# Altium schematic sheet [17] - HEADER, SPI, LEDS, EEPROM.SchDoc("[17] - HEADER, SPI, LEDS, EEPROM")
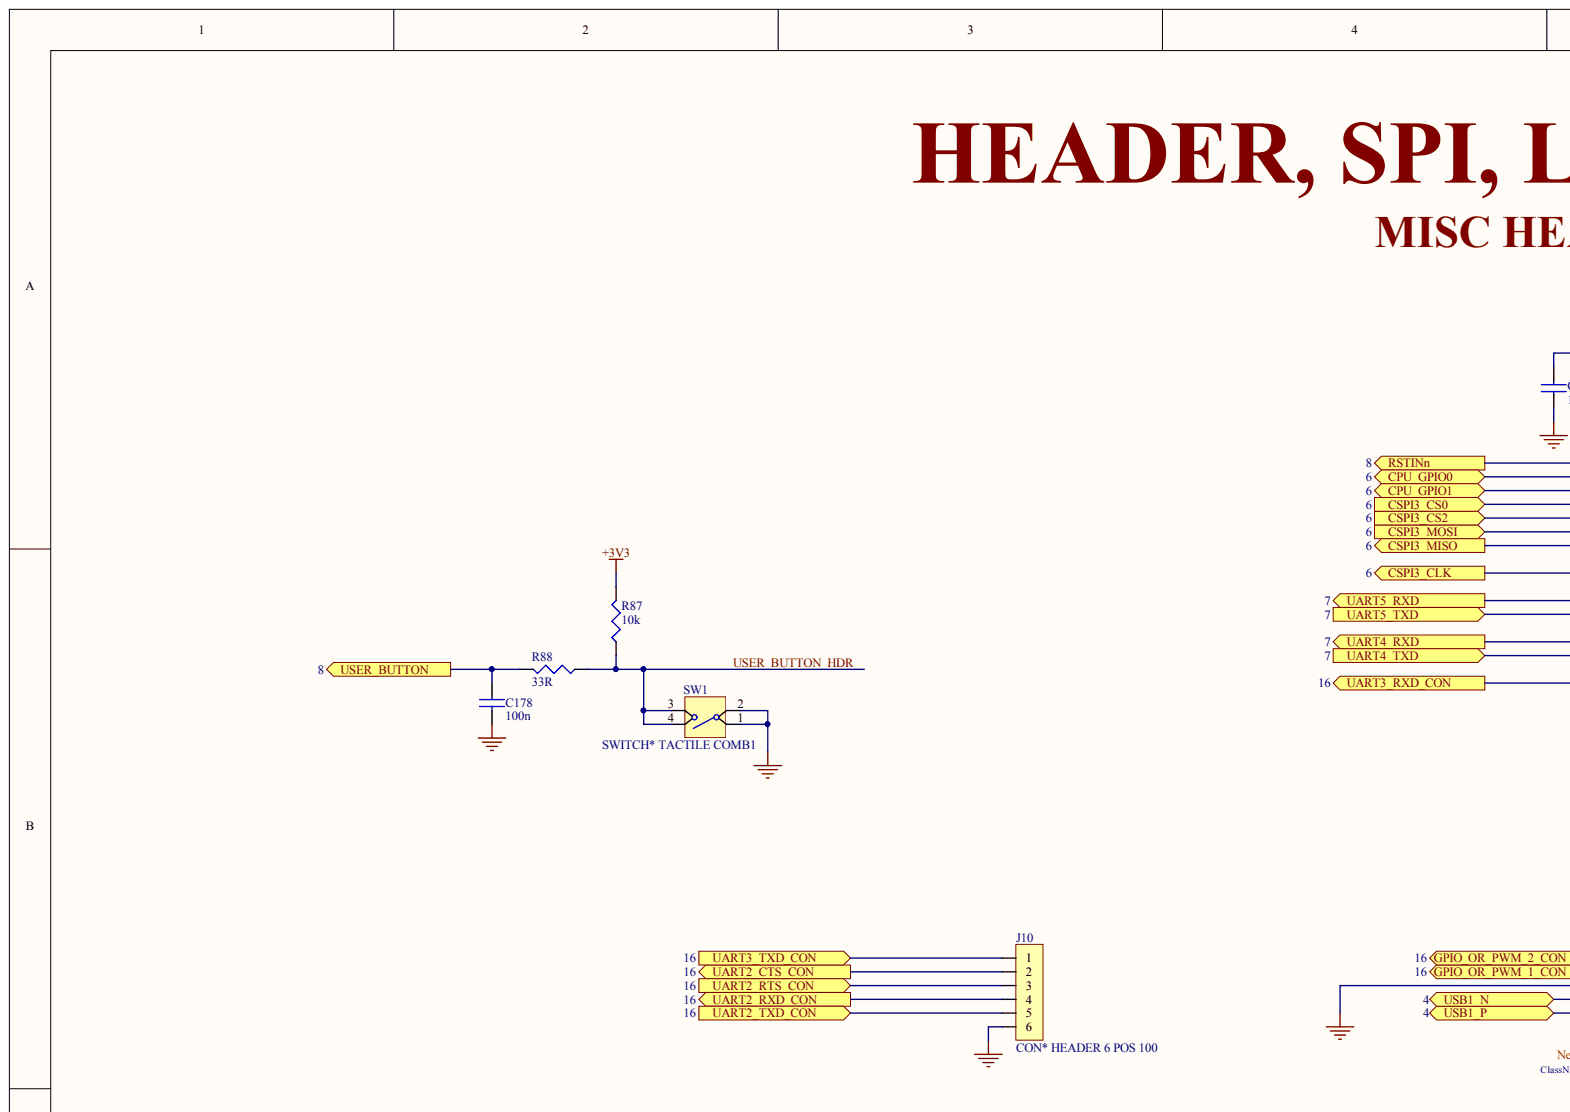
[diagram p0: [17] - HEADER, SPI, LEDS, EEPROM.SchDoc, top left]
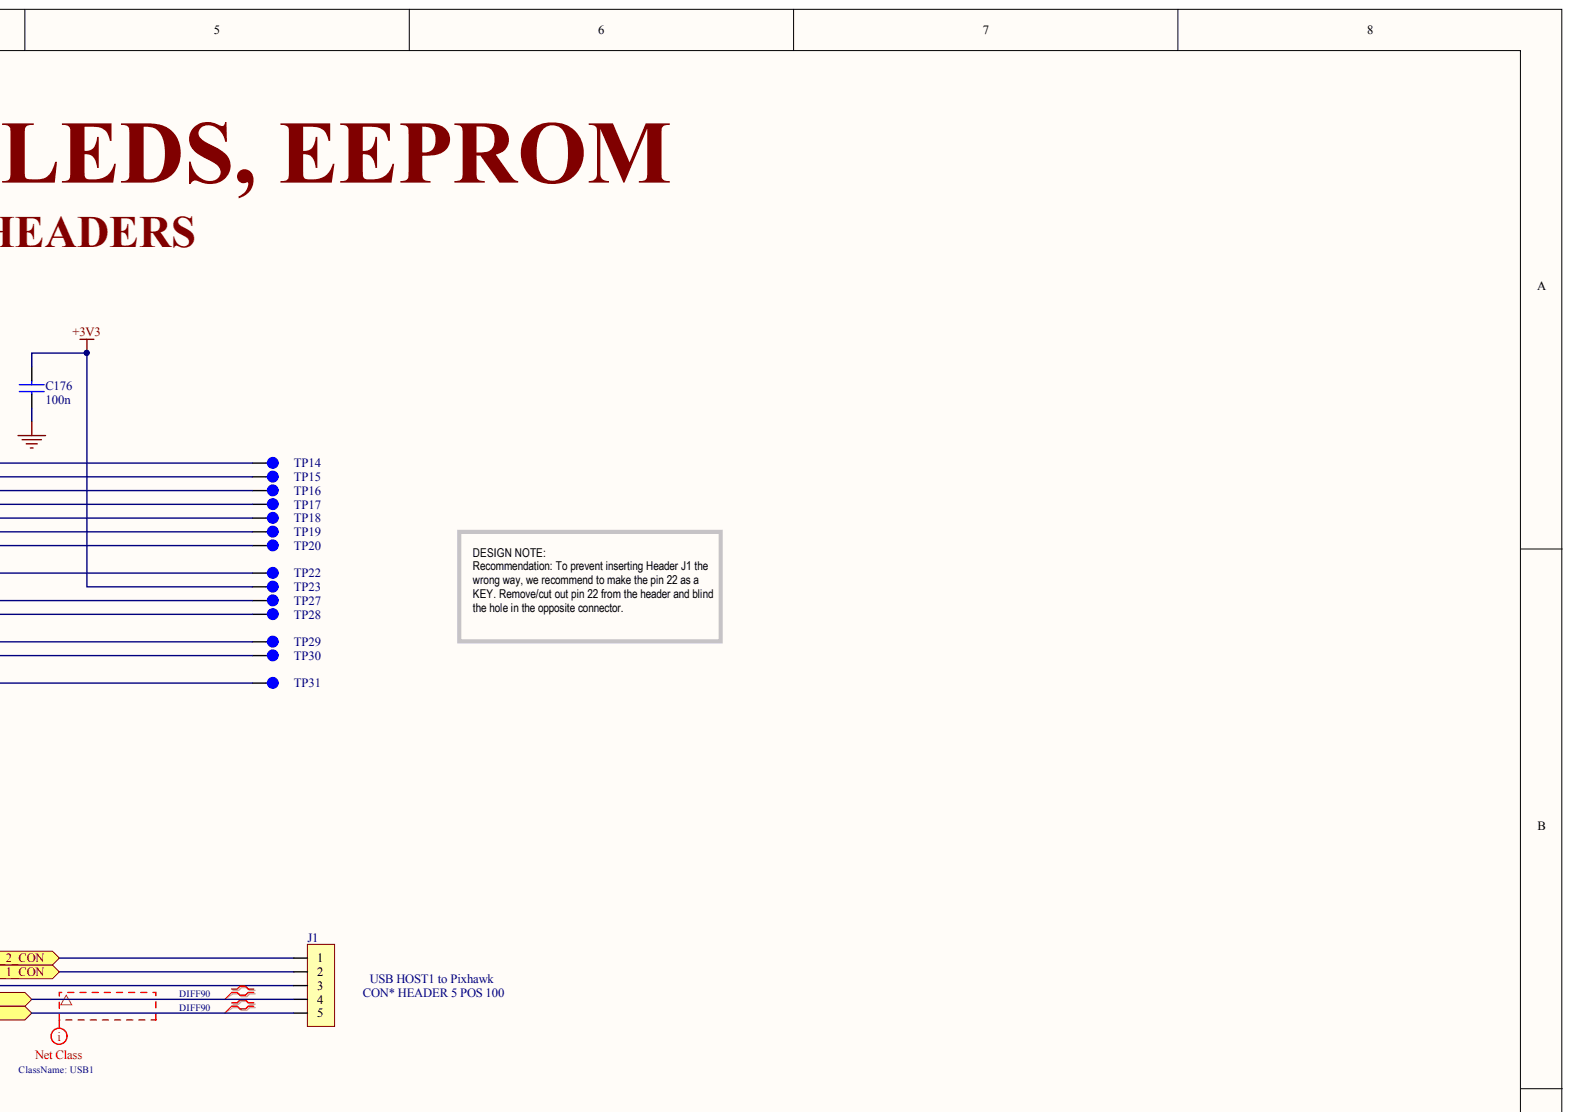
[diagram p1: [17] - HEADER, SPI, LEDS, EEPROM.SchDoc, top right]
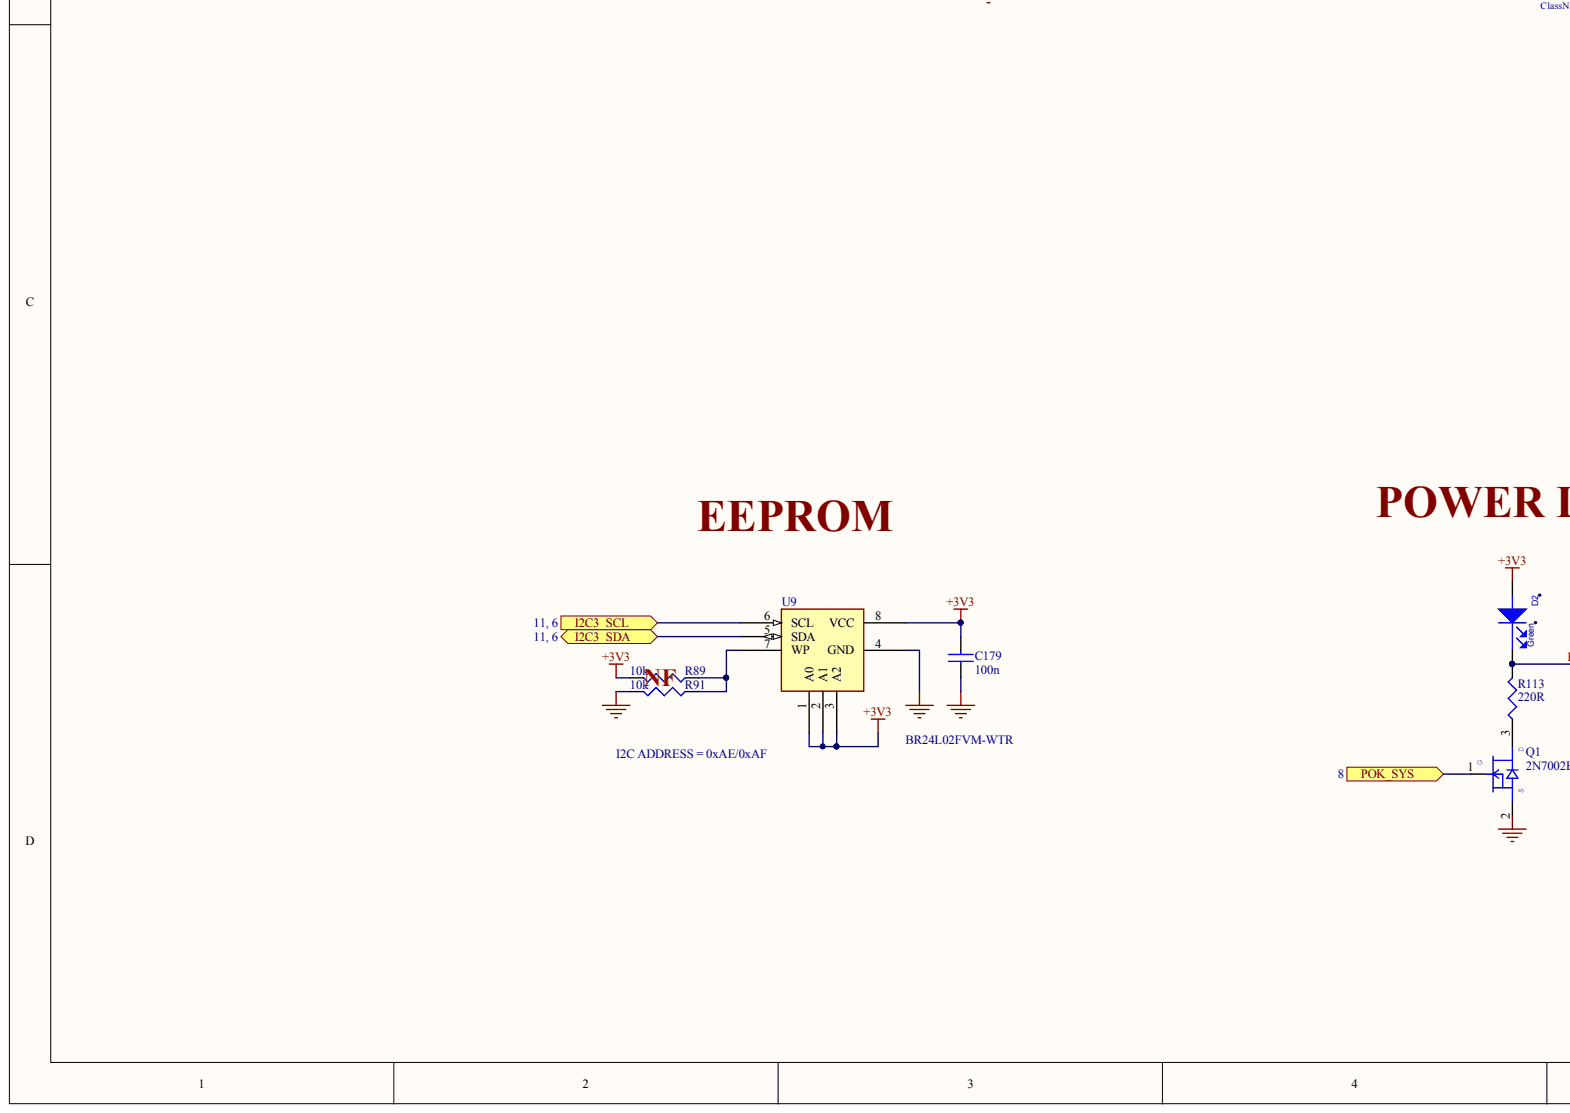
[diagram p2: [17] - HEADER, SPI, LEDS, EEPROM.SchDoc, bottom left]
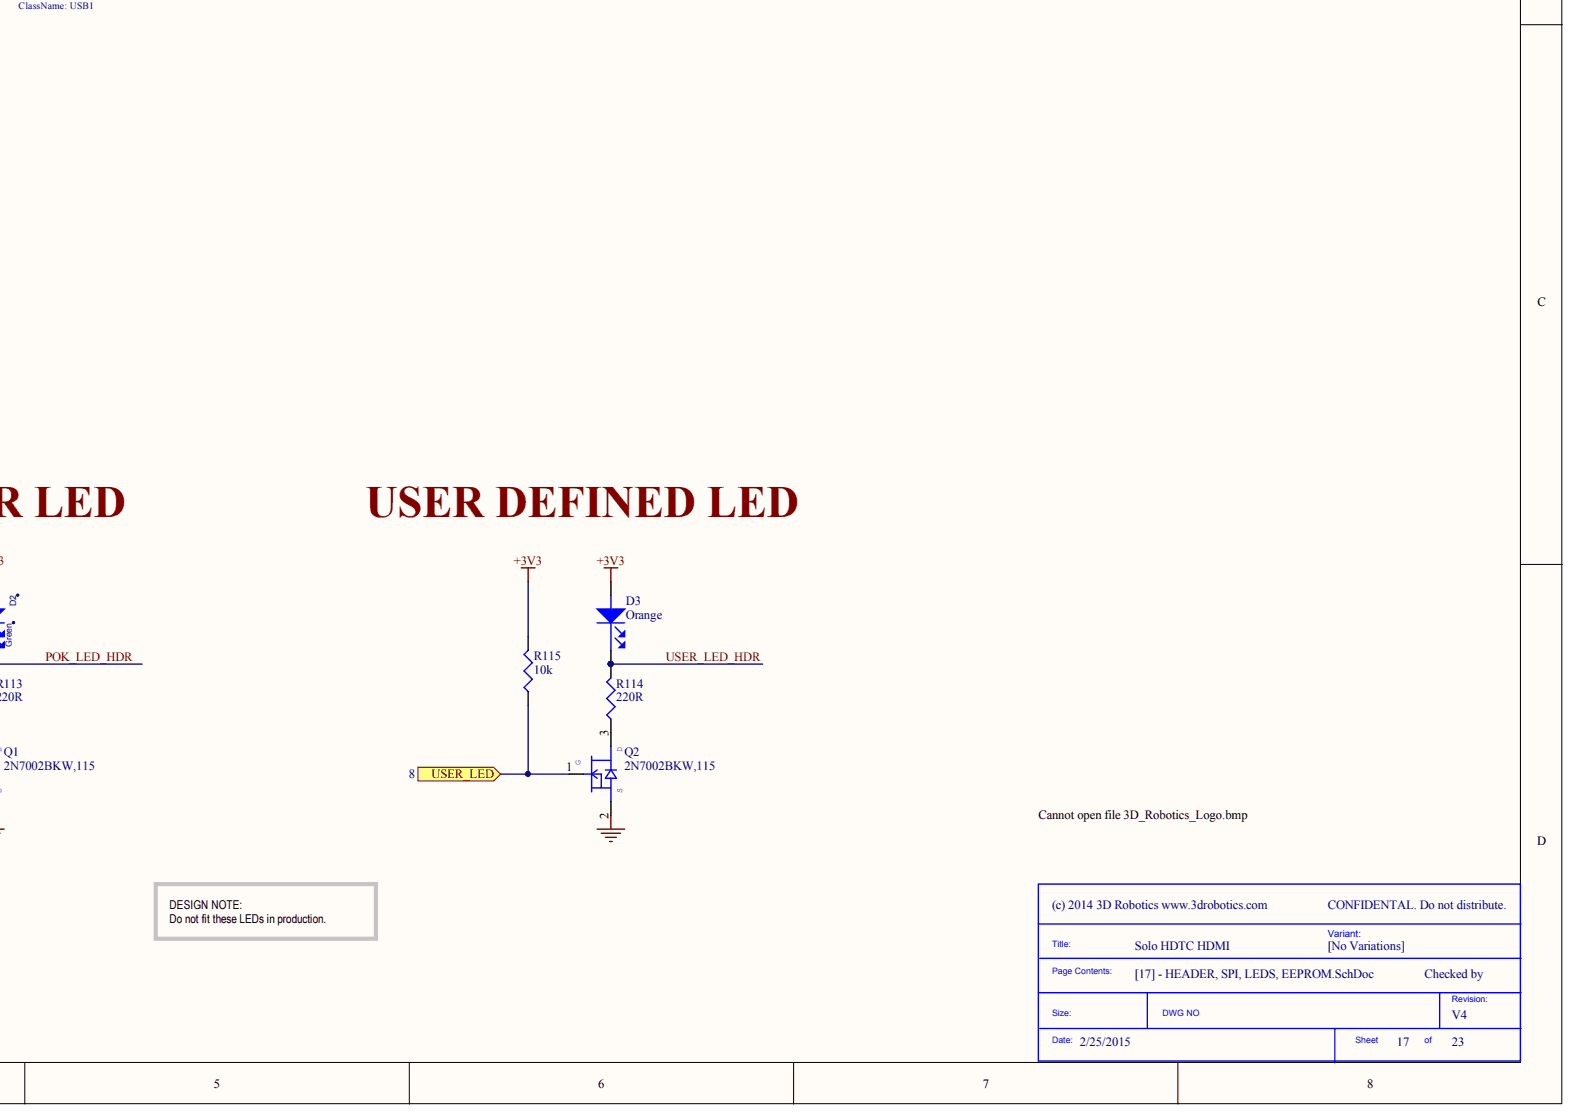
[diagram p3: [17] - HEADER, SPI, LEDS, EEPROM.SchDoc, bottom right]

## Parts
C176  100n
C178  100n
C179  100n
D2  Green
D3  Orange
J1  CON* HEADER 5 POS 100
J10  CON* HEADER 6 POS 100
Q1  2N7002BKW,115
Q2  2N7002BKW,115
R87  10k
R88  33R
R89  10k
R91  10k
R113  220R
R114  220R
R115  10k
SW1  SWITCH* TACTILE COMB1
TP14  test point  (value not drawn)
TP15  test point  (value not drawn)
TP16  test point  (value not drawn)
TP17  test point  (value not drawn)
TP18  test point  (value not drawn)
TP19  test point  (value not drawn)
TP20  test point  (value not drawn)
TP22  test point  (value not drawn)
TP23  test point  (value not drawn)
TP27  test point  (value not drawn)
TP28  test point  (value not drawn)
TP29  test point  (value not drawn)
TP30  test point  (value not drawn)
TP31  test point  (value not drawn)
U9  BR24L02FVM-WTR

## Ports
CPU_GPIO0, CPU_GPIO1, CSPI3_CLK, CSPI3_CS0, CSPI3_CS2, CSPI3_MISO, CSPI3_MOSI, GPIO_OR_PWM_1_CON, GPIO_OR_PWM_2_CON, I2C3_SCL, I2C3_SDA, POK_SYS, RSTINN, UART2_CTS_CON, UART2_RTS_CON, UART2_RXD_CON, UART2_TXD_CON, UART3_RXD_CON, UART3_TXD_CON, UART4_RXD, UART4_TXD, UART5_RXD, UART5_TXD, USB1_N, USB1_P, USER_BUTTON, USER_LED

## Nets
+3V3: C176.2 C179.2 D2.2 D3.2 R87.2 R89.2 R115.2 TP23.1 U9.1(A0) U9.2(A1) U9.3(A2) U9.8(VCC)
GND: C176.1 C178.2 C179.1 J1.3 J10.6 Q1.2 Q2.2 R91.2 SW1.1 SW1.2 U9.4(GND)
RSTINN: TP14.1 ; port RSTINN
CPU_GPIO0: TP15.1 ; port CPU_GPIO0
CPU_GPIO1: TP16.1 ; port CPU_GPIO1
CSPI3_CLK: TP22.1 ; port CSPI3_CLK
CSPI3_CS0: TP17.1 ; port CSPI3_CS0
CSPI3_CS2: TP18.1 ; port CSPI3_CS2
CSPI3_MISO: TP20.1 ; port CSPI3_MISO
CSPI3_MOSI: TP19.1 ; port CSPI3_MOSI
GPIO_OR_PWM_1_CON: J1.2 ; port GPIO_OR_PWM_1_CON
GPIO_OR_PWM_2_CON: J1.1 ; port GPIO_OR_PWM_2_CON
I2C3_SCL: U9.6(SCL) ; port I2C3_SCL
I2C3_SDA: U9.5(SDA) ; port I2C3_SDA
POK_LED_HDR: D2.1 R113.2
POK_SYS: Q1.1 ; port POK_SYS
UART2_CTS_CON: J10.2 ; port UART2_CTS_CON
UART2_RTS_CON: J10.3 ; port UART2_RTS_CON
UART2_RXD_CON: J10.4 ; port UART2_RXD_CON
UART2_TXD_CON: J10.5 ; port UART2_TXD_CON
UART3_RXD_CON: TP31.1 ; port UART3_RXD_CON
UART3_TXD_CON: J10.1 ; port UART3_TXD_CON
UART4_RXD: TP29.1 ; port UART4_RXD
UART4_TXD: TP30.1 ; port UART4_TXD
UART5_RXD: TP27.1 ; port UART5_RXD
UART5_TXD: TP28.1 ; port UART5_TXD
USB1_N: J1.4 ; port USB1_N
USB1_P: J1.5 ; port USB1_P
USER_BUTTON: C178.1 R88.2 ; port USER_BUTTON
USER_BUTTON_HDR: R87.1 R88.1 SW1.3 SW1.4
USER_LED: Q2.1 R115.1 ; port USER_LED
USER_LED_HDR: D3.1 R114.2
NetQ1_3: Q1.3 R113.1
NetQ2_3: Q2.3 R114.1
NetR89_1: R89.1 R91.1 U9.7(WP)
N00729:

## Library data of the parts
C176 C178 C179 = (no symbol name): Manufacturer: TDK Corporation; Manufacturer Part Number: C1005X5R1H104K; Capacitance: 0.1µF; Value: 100nF; .Checked PCB: used; Voltage - Rated: 50V; Tolerance: ±10%; Temperature Coefficient: X5R; Category: Capacitors; Supplier Part Number: 445-5942-1-ND; Operating Temperature: -55°C ~ 85°C; Package / Case: 0402 (1005 Metric); Price 1k [$]: 0.02340; .Checked SCH: used; Supplier: Digikey; Supplier 1: Digi-Key; Supplier Part Number 1: 445-5942-1-ND; RoHS: RoHS Compliant; Stock 1: 106926; Pricing 1: 1=0.27, 10=0.18, 100=0.072, 250=0.05176, 500=0.0369, 1000=0.027 (USD); Mounting Type: Surface Mount, MLCC; Applications: General Purpose; Ratings: -; Size / Dimension: 0.039" L x 0.020" W (1.00mm x 0.50mm); Height - Seated (Max): -; Thickness (Max): 0.022" (0.55mm); Lead Spacing: -; Features: -; Packaging: Cut Tape (CT); Lead Style: -; ComponentLink1URL: http://www.tdk.co.jp/tefe02/e412_c.pdf; ComponentLink1Description: http://www.tdk.co.jp/tefe02/e412_c.pdf
D2 = (no symbol name): Manufacturer: OSRAM Opto Semiconductors Inc; Manufacturer Part Number: LG L29K-G2J1-24-Z; .Checked PCB: used; Current - Test: 2mA; Voltage - Forward (Vf) Typ: 1.7V; Luminous Flux @ Current - Test: 12 mlm; Millicandela Rating: 3.92mcd; Wavelength - Dominant: 570nm; Wavelength - Peak: 572nm; Lens Type: Diffused; Lens Style/Size: Rectangle with Flat Top, 1.3mm x 0.8mm; Viewing Angle: 160°; Regulator Topology: Positive Fixed; Voltage - Output: 2.5V; Voltage - Input: 2.6 V ~ 6 V; Voltage - Dropout (Typical): 0.16V @ 500mA; Number of Regulators: 1; Current - Output: 500mA; Current - Limit (Min): 600mA; .Checked SCH: used; Category: Optoelectronics; Family: LEDs - <75mA, Discre; Price 1k [$]: 0.08744; Value: Green; Color: Green; Package / Case: 0603 (1608 Metric); Supplier Part Number: 475-2709-1-ND; Supplier: Digikey; Supplier 1: Digi-Key; Supplier Part Number 1: 475-2709-1-ND; RoHS: RoHS Compliant; Stock 1: 30318; Pricing 1: 1=0.16, 10=0.137, 100=0.1093, 250=0.09564, 500=0.08744 (USD); Size / Dimension: 1.70mm L x 0.80mm W; Height: 0.65mm; Mounting Type: Surface Mount; Packaging: Cut Tape (CT); ComponentLink1URL: http://catalog.osram-os.com/catalogue/catalogue.do;jsessionid=32CB61882C089EDD4B6D0A54E7E1F117?act=downloadFile&favOid=020000020000138e000100b6; ComponentLink1Description: http://catalog.osram-os.com/catalogue/catalogue.do;jsessionid=32CB61882C089EDD4B6D0A54E7E1F117?act=downloadFile&favOid=020000020000138e000100b6; Operating Temperature: -40°C ~ 125°C; Supplier Device Package: 6-TMLF® (1.6x1.6); ComponentLink2URL: http://www.micrel.com/_PDF/mic5353.pdf; ComponentLink2Description: http://www.micrel.com/_PDF/mic5353.pdf
D3 = (no symbol name): Manufacturer: OSRAM Opto Semiconductors Inc; Manufacturer Part Number: LO L29K-H2K1-24-Z; Millicandela Rating: 6.3mcd; Voltage - Forward (Vf) Typ: 1.8V; Current - Test: 2mA; Wavelength - Dominant: 606nm; Wavelength - Peak: 610nm; Viewing Angle: 160°; Lens Type: Diffused; Lens Style/Size: Rectangle with Flat Top, 1.3mm x 0.8mm; Luminous Flux @ Current - Test: 25 mlm; .Checked PCB: RF; Supplier 1: Digi-Key; Supplier Part Number 1: 475-2740-1-ND; RoHS: RoHS Compliant; Category: Optoelectronics; Stock 1: 15900; Pricing 1: 1=0.17, 10=0.141, 100=0.1127, 250=0.0986, 500=0.09016 (USD); Color: Orange; Package / Case: 0603 (1608 Metric); Size / Dimension: 1.70mm L x 0.80mm W; Height: 0.65mm; Mounting Type: Surface Mount; Packaging: Cut Tape (CT); ComponentLink1URL: http://catalog.osram-os.com/catalogue/catalogue.do;jsessionid=302CF83AF637AE24E40AEB0ADA267EDD?act=downloadFile&favOid=020000010003f9de000100b6; ComponentLink1Description: http://catalog.osram-os.com/catalogue/catalogue.do;jsessionid=302CF83AF637AE24E40AEB0ADA267EDD?act=downloadFile&favOid=020000010003f9de000100b6; .Checked SCH: RF
Q1 Q2 = (no symbol name): Manufacturer: NXP Semiconductors; FET Type: MOSFET N-Channel, Metal Oxide; FET Feature: Logic Level Gate; Drain to Source Voltage (Vdss): 60V; Current - Continuous Drain (Id) @ 25° C: 310mA; Rds On (Max) @ Id, Vgs: 1.6 Ohm @ 500mA, 10V; Vgs(th) (Max) @ Id: 2.1V @ 250µA; Gate Charge (Qg) @ Vgs: 0.6nC @ 4.5V; Input Capacitance (Ciss) @ Vds: 50pF @ 10V; Power - Max: 275mW; .Checked PCB: RF; Supplier 1: Digi-Key; Supplier Part Number 1: 568-5980-1-ND; Manufacturer Part Number: 2N7002BKW,115; RoHS: RoHS Compliant; Category: Discrete Semiconductor Products; Stock 1: 15666; Pricing 1: 1=0.39, 10=0.354, 25=0.2548, 100=0.1983, 250=0.12456, 500=0.10616, 1000=0.07233 (USD); Mounting Type: Surface Mount; Package / Case: SC-70, SOT-323; Supplier Device Package: SC-70; Packaging: Cut Tape (CT); ComponentLink1URL: http://www.nxp.com/documents/data_sheet/2N7002BKW.pdf; ComponentLink1Description: http://www.nxp.com/documents/data_sheet/2N7002BKW.pdf; .Checked SCH: RF
R87 R89 R91 R115 = (no symbol name): Manufacturer: Yageo; Manufacturer Part Number: RC0402FR-0710KL; Power (Watts): 0.063W, 1/16W; Tolerance: ±1%; .Checked PCB: used; Temperature Coefficient: ±100ppm/°C; Category: Resistors; Supplier Part Number: 311-10.0KLRCT-ND; Package / Case: 0402 (1005 Metric); Price 1k [$]: 0.01421; Resistance (Ohms): 10K; Value: 10k; .Checked SCH: used; Supplier: Digikey; Supplier 1: Digi-Key; Supplier Part Number 1: 311-10.0KLRCT-ND; RoHS: RoHS Compliant; Stock 1: 2916495; Pricing 1: 10=0.014, 50=0.0104, 100=0.0079, 250=0.00584, 500=0.00432, 1000=0.00288 (USD); Composition: Thick Film; Features: -; Size / Dimension: 0.039" L x 0.020" W (1.00mm x 0.50mm); Height: 0.016" (0.40mm); Number of Terminations: 2; Packaging: Cut Tape (CT); ComponentLink1URL: http://www.yageo.com/documents/recent/PYu-RC0402_51_RoHS_L_6.pdf; ComponentLink1Description: http://www.yageo.com/documents/recent/PYu-RC0402_51_RoHS_L_6.pdf
R88 = (no symbol name): Manufacturer: Stackpole Electronics Inc; Manufacturer Part Number: RMCF0402FT33R0; Resistance (Ohms): 33; Power (Watts): 0.063W, 1/16W; Tolerance: ±1%; .Checked PCB: RF; Temperature Coefficient: ±100ppm/°C; Category: Resistors; Supplier Part Number: RMCF0402FT33R0CT-ND; Package / Case: 0402 (1005 Metric); Price 1k [$]: 0.00384; Value: 33R; Supplier: Digikey; Supplier 1: Digi-Key; Supplier Part Number 1: RMCF0402FT33R0CT-ND; RoHS: RoHS Compliant; Stock 1: 26866; Pricing 1: 1=0.04, 10=0.025, 50=0.0138, 100=0.0105, 250=0.0078, 500=0.00576, 1000=0.00384 (USD); Composition: Thick Film; Features: -; Size / Dimension: 0.039" L x 0.020" W (1.00mm x 0.50mm); Height: 0.016" (0.40mm); Number of Terminations: 2; Packaging: Cut Tape (CT); ComponentLink1URL: http://www.seielect.com/Catalog/SEI-RMCF.pdf; ComponentLink1Description: http://www.seielect.com/Catalog/SEI-RMCF.pdf; .Checked SCH: RF
R113 R114 = (no symbol name): Manufacturer: Yageo; Manufacturer Part Number: RC0402FR-07220RL; Resistance (Ohms): 220; Power (Watts): 0.063W, 1/16W; Tolerance: ±1%; .Checked PCB: used; Temperature Coefficient: ±100ppm/°C; Category: Resistors; Package / Case: 0402 (1005 Metric); Price 1k [$]: 0.01421; Value: 220R; .Checked SCH: used; Supplier Part Number: 311-220LRCT-ND; Supplier: Digikey; Supplier 1: Digi-Key; Supplier Part Number 1: 311-220LRCT-ND; RoHS: RoHS Compliant; Stock 1: 276463; Pricing 1: 10=0.014, 50=0.0104, 100=0.0079, 250=0.00584, 500=0.00432, 1000=0.00288 (USD); Composition: Thick Film; Features: -; Size / Dimension: 0.039" L x 0.020" W (1.00mm x 0.50mm); Height: 0.016" (0.40mm); Number of Terminations: 2; Packaging: Cut Tape (CT); ComponentLink1URL: http://www.yageo.com/documents/recent/PYu-RC0402_51_RoHS_L_6.pdf; ComponentLink1Description: http://www.yageo.com/documents/recent/PYu-RC0402_51_RoHS_L_6.pdf
SW1 = (no symbol name): Manufacturer: Tyco Electronics; Part Number: 1571626-3; Manufacturer2: Omron; Manufacturer3: E-Switch; Part Number2: B3FS-1000P; Part Number3: TL3303F100QG
U9 = (no symbol name): Manufacturer: Rohm Semiconductor; Format - Memory: EEPROMs - Serial; Memory Type: EEPROM; Memory Size: 2K (256 x 8); Speed: 400kHz; Interface: I²C, 2-Wire Serial; Voltage - Supply: 1.8 V ~ 5.5 V; .Checked PCB: RF; Supplier 1: Digi-Key; Supplier Part Number 1: BR24L02FVM-WCT-ND; Manufacturer Part Number: BR24L02FVM-WTR; RoHS: RoHS Compliant; Category: Integrated Circuits (ICs); Stock 1: 19923; Pricing 1: 1=0.78, 25=0.602, 100=0.531, 250=0.4602, 500=0.3894, 1000=0.30975 (USD); Operating Temperature: -40°C ~ 85°C; Package / Case: 8-VSSOP, 8-MSOP (0.110", 2.80mm Width); Supplier Device Package: 8-MSOP; Packaging: Cut Tape (CT); ComponentLink1URL: http://www.rohm.com/products/databook/eeprom/pdf/br24l-e.pdf; ComponentLink1Description: http://www.rohm.com/products/databook/eeprom/pdf/br24l-e.pdf; .Checked SCH: RF
J1 J10 TP14-TP20 TP22 TP23 TP27-TP31 = (no symbol name)
  TP14-TP20 TP22 TP23 TP27-TP31: Value: test point
